# Altium schematic sheet FTDI.SchDoc(FTDI)
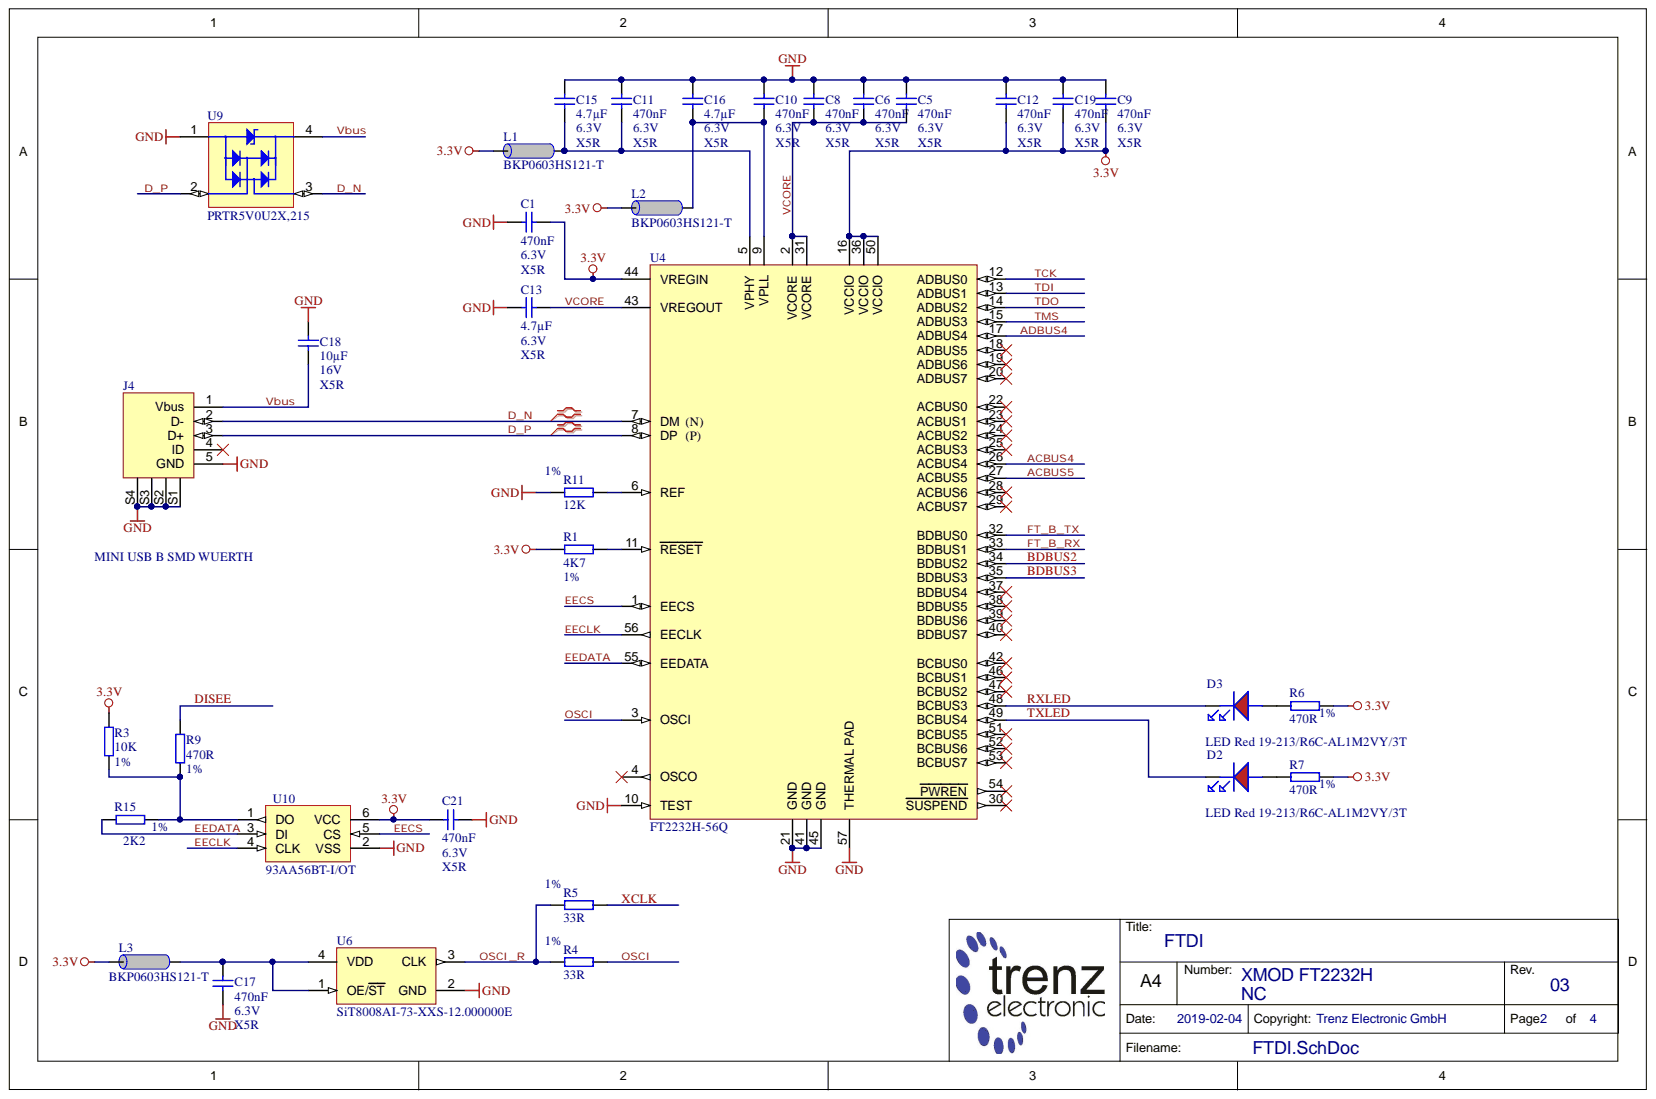
[diagram p0: FTDI.SchDoc]

## Parts
C1  470nF  Voltage: 6.3V  [CAPC0603X33N]
C5  470nF  Voltage: 6.3V  Technology: X5R  [CAPC0603X33N]
C6  470nF  Voltage: 6.3V  Technology: X5R  [CAPC0603X33N]
C8  470nF  Voltage: 6.3V  Technology: X5R  [CAPC0603X33N]
C9  470nF  Voltage: 6.3V  Technology: X5R  [CAPC0603X33N]
C10  470nF  Voltage: 6.3V  Technology: X5R  [CAPC0603X33N]
C11  470nF  Voltage: 6.3V  Technology: X5R  [CAPC0603X33N]
C12  470nF  Voltage: 6.3V  Technology: X5R  [CAPC0603X33N]
C13  4.7µF  Voltage: 6.3V  Technology: X5R  [CAPC1005X60N]
C15  4.7µF  Voltage: 6.3V  [CAPC1005X60N]
C16  4.7µF  Voltage: 6.3V  Technology: X5R  [CAPC1005X60N]
C17  470nF  Voltage: 6.3V  Technology: X5R  [CAPC0603X33N]
C18  10µF  Voltage: 16V  Technology: X5R  [CAPC1608X90N]
C19  470nF  Voltage: 6.3V  Technology: X5R  [CAPC0603X33N]
C21  470nF  Voltage: 6.3V  [CAPC0603X33N]
D2  LED Red 19-213/R6C-AL1M2VY/3T  H-ART: 19-213/R6C-AL1M2VY/3T  Database Table Name: LED  [LEDC1608X55N_RED]
D3  LED Red 19-213/R6C-AL1M2VY/3T  [LEDC1608X55N_RED]
J4  MINI USB B SMD WUERTH  [MINI USB 5S B TYPE]
L1  BKP0603HS121-T  [INDC0603X33N]
L2  BKP0603HS121-T  [INDC0603X33N]
L3  BKP0603HS121-T  [INDC0603X33N]
R1  4K7  Tolerance: 1%  [RESC0603X26N]
R3  10K  Tolerance: 1%  [RESC0603X26N]
R4  33R  Tolerance: 1%  [RESC0603X26N]
R5  33R  Tolerance: 1%  [RESC0603X26N]
R6  470R  Tolerance: 1%  [RESC1005X40N]
R7  470R  Tolerance: 1%  [RESC1005X40N]
R9  470R  Tolerance: 1%  [RESC1005X40N]
R11  12K  Tolerance: 1%  [RESC1005X40N]
R15  2K2  Tolerance: 1%  [RESC1005X40N]
U4  FT2232H-56Q  [QFN50P800X800-57T610X610N]
U6  SiT8008AI-73-XXS-12.000000E  Database Table Name: CLK  [2016]
U9  PRTR5V0U2X,215  [SOT190P229X114-4N]
U10  93AA56BT-I/OT  [SOT95P280X145-6N]

## Nets
3.3V: C1.2 C9.1 C12.1 C19.1 C21.1 L1.2 L2.2 L3.2 R1.1 R3.1 R6.1 R7.1 U4.16(VCCIO) U4.36(VCCIO) U4.44(VREGIN) U4.50(VCCIO) U10.6(VCC)
GND: C1.1 C5.2 C6.2 C8.2 C9.2 C10.2 C11.2 C12.2 C13.1 C15.2 C16.2 C17.1 C18.1 C19.2 C21.2 J4.5(GND) J4.S1 J4.S2 J4.S3 J4.S4 R11.1 U4.10(TEST) U4.21(GND) U4.41(GND) U4.45(GND) U4.57(THERMAL_PAD) U6.2(GND) U9.1 U10.2(VSS)
ACBUS4: U4.26(ACBUS4)
ACBUS5: U4.27(ACBUS5)
ADBUS4: U4.17(ADBUS4)
BDBUS2: U4.34(BDBUS2)
BDBUS3: U4.35(BDBUS3)
D_N: J4.2(D-) U4.7(DM) U9.3
D_P: J4.3(D+) U4.8(DP) U9.2
DISEE: R9.1
EECLK: U4.56(EECLK) U10.4(CLK)
EECS: U4.1(EECS) U10.5(CS)
EEDATA: R15.1 U4.55(EEDATA) U10.3(DI)
FT_B_RX: U4.33(BDBUS1)
FT_B_TX: U4.32(BDBUS0)
OSCI: R4.2 U4.3(OSCI)
OSCI_R: R4.1 R5.1 U6.3(CLK)
RXLED: D3.K U4.48(BCBUS3)
TCK: U4.12(ADBUS0)
TDI: U4.13(ADBUS1)
TDO: U4.14(ADBUS2)
TMS: U4.15(ADBUS3)
TXLED: D2.K U4.49(BCBUS4)
Vbus: C18.2 J4.1(Vbus) U9.4
VCORE: C5.1 C6.1 C8.1 C13.2 U4.2(VCORE) U4.31(VCORE) U4.43(VREGOUT)
XCLK: R5.2
NetC10_1: C10.1 C16.1 L2.1 U4.9(VPLL)
NetC11_1: C11.1 C15.1 L1.1 U4.5(VPHY)
NetC17_2: C17.2 L3.1 U6.1(OE/ST) U6.4(VDD)
NetD2_A: D2.A R7.2
NetD3_A: D3.A R6.2
NetR1_2: R1.2 U4.11(RESET)
NetR3_2: R3.2 R9.2 R15.2 U10.1(DO)
NetR11_2: R11.2 U4.6(REF)

Not connected: J4 4(ID); U4 4(OSCO) 18(ADBUS5) 19(ADBUS6) 20(ADBUS7) 22(ACBUS0) 23(ACBUS1) 24(ACBUS2) 25(ACBUS3) 28(ACBUS6) 29(ACBUS7) 30(SUSPEND) 37(BDBUS4) 38(BDBUS5) 39(BDBUS6) 40(BDBUS7) 42(BCBUS0) 46(BCBUS1) 47(BCBUS2) 51(BCBUS5) 52(BCBUS6) 53(BCBUS7) 54(PWREN)

## Library data of the parts
C1 C5 C6 C8-C12 C17 C19 C21 = 24921: KON 470nF 6.3V X5R 20%  SMD BF 0603 (0201); Value: 470n; Temperature-Range: -55/+85; Preferred: Yes; Mixed: SMT; Database Table Name: C_0603_0201; Tolerance: 20%; Library Name: TE.DbLib; Component Kind: Standard; Case: 0603 (0201); AN: 24921
  C1 C21: Technology: X5R
C13 C15 C16 = 24719: KON 4.7µF 6.3V X5R 20% SMD BF 1005 (0402); Value: 4.7u; Temperature-Range: -55/+85; Preferred: Yes; Mixed: SMT; Database Table Name: C_1005_0402; Tolerance: 20%; Library Name: TE.DbLib; Component Kind: Standard; Case: 1005 (0402); AN: 24719
  C15: Technology: X5R
C18 = 25341: KON 10µF 16V X5R 20% SMD BF 1608 (0603); Value: 10u; Temperature-Range: -55/+85; Preferred: Yes; Mixed: SMT; Database Table Name: C_1608_0603; Tolerance: 20%; Library Name: TE.DbLib; Component Kind: Standard; Case: 1608 (0603); AN: 25341
D2 D3 = 28261: Chip LED 0603 Briliant Red; Mixed: SMT; Library Name: TE.DbLib; Component Kind: Standard; Case: 1608 (0603); AN: 28261; HelpURL: b:\AD\adlib\datasheet\19-213-R6C\
  D3: H-ART: 19-213/R6C-AL1M2VY/3T; Database Table Name: LED
J4 = 24295: STE USB MINI B LP Buchse SMD; Trenz-Stock: Yes; Mixed: SMT; H-ART: 65100516121; Database Table Name: CON_IO; Library Name: TE.DbLib; Component Kind: Standard; AN: 24295; HelpURL: B:\AD\adlib\datasheet\65100516121
L1-L3 = 25393: Ferritdurchführung SMD 0201, BKP0603HS121-T; Trenz-Stock: Yes; Tolerance: 25%; Temperature-Range: -55/+85; Mixed: SMT; Database Table Name: L_0603_0201; Preferred: Yes; Library Name: TE.DbLib; H-ART: BKP0603HS121-T; Component Kind: Standard; Case: 0603 (0201); AN: 25393; HelpURL: B:\AD\adlib\datasheet\MULTILAYER CHIP BEAD INDUCTORS BK
R1 = 25242: WID SMD 4K7 1% 0,05W  BF 0603 (0201); Value: 4.7K; Preferred: Yes; Mixed: SMT; H-ART: RC0201FR-074K7L; Database Table Name: R_0603_0201; Technology: TK100; Library Name: TE.DbLib; Component Kind: Standard; Case: 0603 (0201); AN: 25242; HelpURL: B:\AD\adlib\datasheet\R0201
R3 = 24914: WID SMD 10K 1% 0,05W BF 0603 (0201); Preferred: Yes; Mixed: SMT; H-ART: RC0201FR-0710KL; Database Table Name: R_0603_0201; Value: 10K; Technology: TK100; Library Name: TE.DbLib; Component Kind: Standard; Case: 0603 (0201); AN: 24914; HelpURL: B:\AD\adlib\datasheet\R0201
R4 R5 = 25021: WID SMD 33R 1% 0,05W  BF 0603 (0201); Value: 33; Preferred: Yes; Mixed: SMT; H-ART: RC0201FR-0733RL; Database Table Name: R_0603_0201; Technology: TK100; Library Name: TE.DbLib; Component Kind: Standard; Case: 0603 (0201); AN: 25021; HelpURL: B:\AD\adlib\datasheet\R0201
R6 R7 R9 = 22769: WID SMD 470R 1% 0,063W BF 1005 (0402); Value: 470; Preferred: Yes; Mixed: SMT; Database Table Name: R_1005_0402; Technology: TK100; Library Name: TE.DbLib; Component Kind: Standard; Case: 1005 (0402); AN: 22769
R11 = 22598: WID SMD 12K 1% 0,063W  BF 1005 (0402); Preferred: Yes; Mixed: SMT; Database Table Name: R_1005_0402; Value: 12K; Technology: TK100; Library Name: TE.DbLib; Component Kind: Standard; Case: 1005 (0402); AN: 22598
R15 = 22748: WID SMD 2K2 1% 0,063W BF 1005 (0402); Value: 2.2K; Preferred: Yes; Mixed: SMT; H-ART: RC0402FR-132K2L; Database Table Name: R_1005_0402; Technology: TK100; Library Name: TE.DbLib; Component Kind: Standard; Case: 1005 (0402); AN: 22748
U4 = 27277: Trenz-Stock: Yes; Temperature-Range: -40/+85; Mixed: SMT; Database Table Name: IF; Preferred: Yes; Library Name: TE.DbLib; H-ART: FT2232H-56Q; Description: FT2232H-56Q; Component Kind: Standard; Case: VQFN56; AN: 27277; HelpURL: B:\AD\adlib\datasheet\FT2232H
U6 = 25325: SiT8008AI-73-XXS-12.000000E MEMS Oszillator 12MHz; Value: 12M; Trenz-Stock: Yes; Temperature-Range: -40/+85; Mixed: SMT; Preferred: Yes; Library Name: TE.DbLib; H-ART: SiT8008AI-73-XXS-12.000000E; Component Kind: Standard; Case: 2.0  x 1.6mm; AN: 25325; HelpURL: B:\AD\adlib\datasheet\SiT8008
U9 = 24840: Temperature-Range: -40/+85; Preferred: Yes; Mixed: SMT; Database Table Name: DIODE; Library Name: TE.DbLib; H-ART: PRTR5V0U2X,215; Description: PRTR5V0U2X,215; Component Kind: Standard; Case: SOT143; AN: 24840; HelpURL: B:\AD\adlib\datasheet\CD143A-SR05
U10 = 24730: Trenz-Stock: Yes; Temperature-Range: -40/+85; Mixed: SMT; Database Table Name: EEPROM; Preferred: Yes; Library Name: TE.DbLib; H-ART: 93AA56BT-I/OT; Description: 93AA56BT-I/OT; Component Kind: Standard; Case: SOT23-6; AN: 24730; HelpURL: B:\AD\adlib\datasheet\93AA56A
